# Altium schematic sheet Schematic.SchDoc(Schematic)
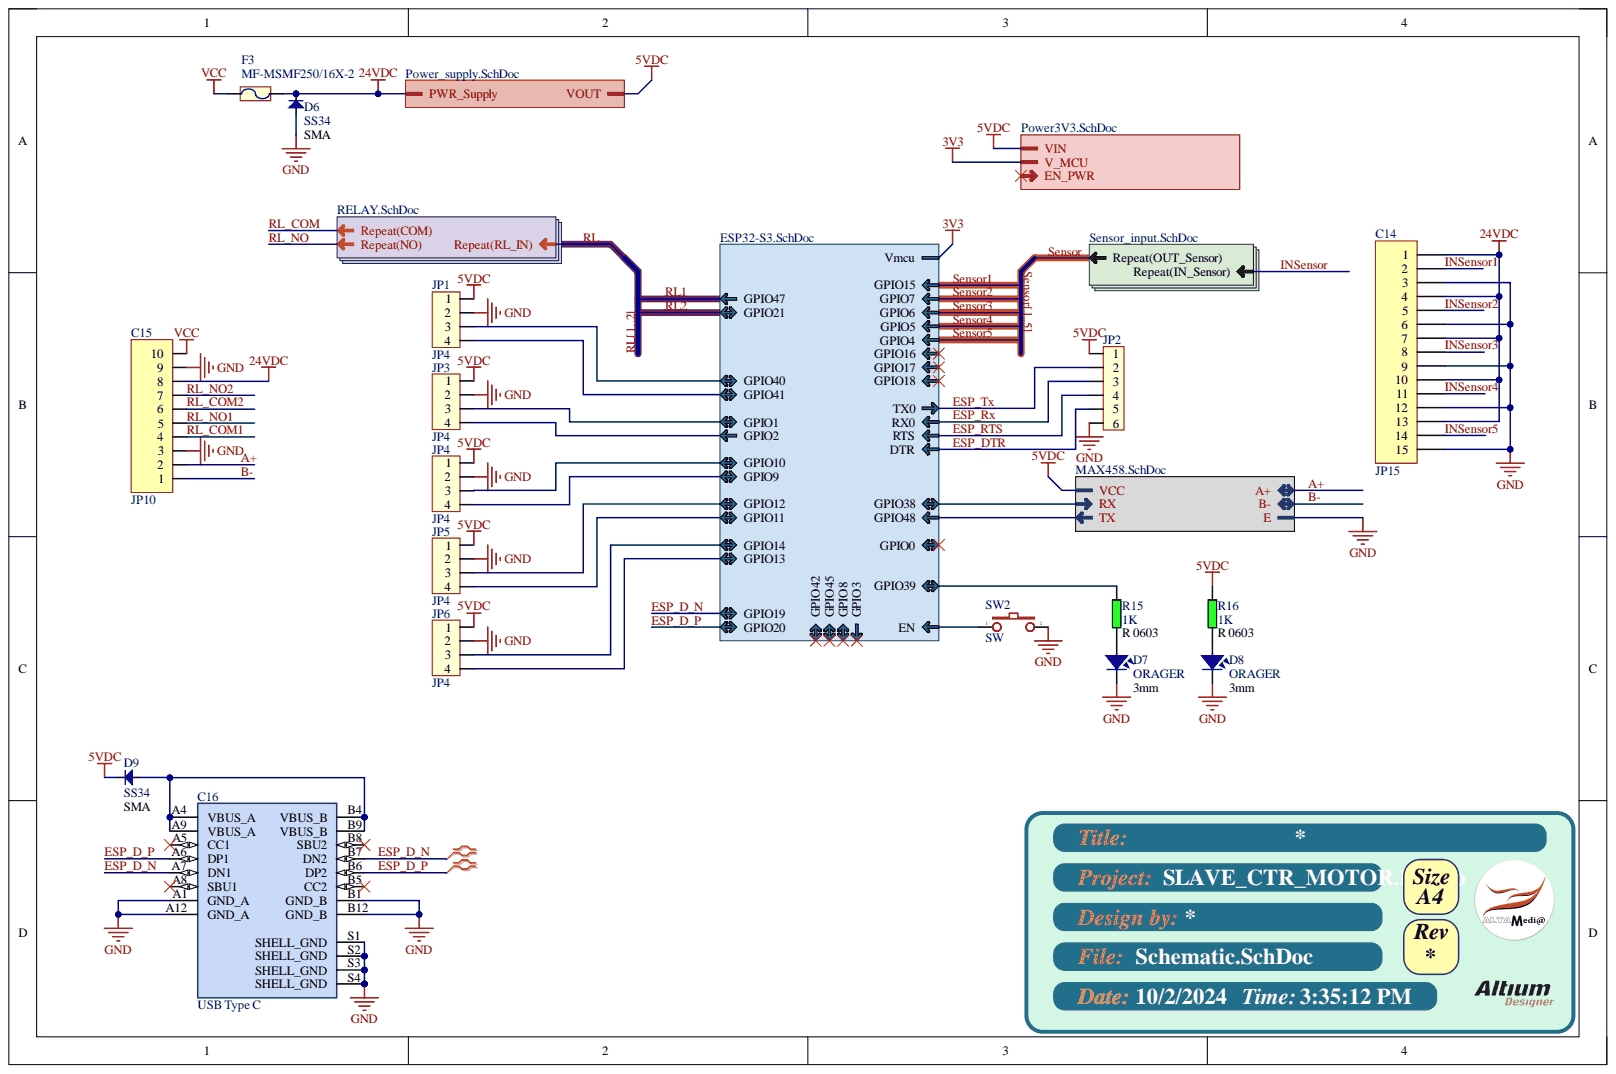
[diagram p0: Schematic.SchDoc]

## Parts
C14  JP15  [KF141-2.54-15]
C15  JP10  [KF141-2.54-10-R]
C16  USB Type C  [USB Type C 16P]
D6  SS34  Size: SMA  [DIO-SMD-106]
D7  ORAGER  Size: 3mm  [LED_RED_3MM]
D8  ORAGER  Size: 3mm  [LED_RED_3MM]
D9  SS34  Size: SMA  [DIO-SMD-106]
F3  MF-MSMF250/16X-2  [FUSER_MF-MSMF250/16X]
JP1  JP4  [CON4]
JP2  (no value)  [JP6]
JP3  JP4  [CON4]
JP4  JP4  [CON4_H]
JP5  JP4  [CON4_H]
JP6  JP4  [CON4_H]
R15  1K  [R-0603]
R16  1K  [R-0603]
SW2  SW  [TS36CA-0.6]

## Nets
5VDC: D9.2 JP1.1 JP2.1 JP3.1 JP4.1 JP5.1 JP6.1 R16.1
24VDC: C14.1 C14.4 C14.7 C14.10 C14.13 C15.8 D6.2 F3.2
GND: C14.3 C14.6 C14.9 C14.12 C14.15 C15.3 C15.9 C16.A1(GND_A) C16.A12(GND_A) C16.B1(GND_B) C16.B12(GND_B) C16.S1(SHELL_GND) C16.S2(SHELL_GND) C16.S3(SHELL_GND) C16.S4(SHELL_GND) D6.1 D7.2 D8.2 JP1.2 JP2.6 JP3.2 JP4.2 JP5.2 JP6.2 SW2.2
VCC: C15.10 F3.1
A+: C15.2
B-: C15.1
ESP_D_N: C16.A7(DN1) C16.B7(DN2)
ESP_D_P: C16.A6(DP1) C16.B6(DP2)
ESP_DTR: JP2.5
ESP_RTS: JP2.4
ESP_Rx: JP2.3
ESP_Tx: JP2.2
INSensor1: C14.2
INSensor2: C14.5
INSensor3: C14.8
INSensor4: C14.11
INSensor5: C14.14
RL_COM1: C15.4
RL_COM2: C15.6
RL_NO1: C15.5
RL_NO2: C15.7
NetC16_A4: C16.A4(VBUS_A) C16.A9(VBUS_A) C16.B4(VBUS_B) C16.B9(VBUS_B) D9.1
NetC16_A5: C16.A5(CC1)
NetC16_A8: C16.A8(SBU1)
NetC16_B5: C16.B5(CC2)
NetC16_B8: C16.B8(SBU2)
NetD7_1: D7.1 R15.2
NetD8_1: D8.1 R16.2
NetJP1_3: JP1.3
NetJP1_4: JP1.4
NetJP3_3: JP3.3
NetJP3_4: JP3.4
NetJP4_3: JP4.3
NetJP4_4: JP4.4
NetJP5_3: JP5.3
NetJP5_4: JP5.4
NetJP6_3: JP6.3
NetJP6_4: JP6.4
NetR15_1: R15.1
NetSW2_1: SW2.1
3V3:
EN_PWR:
GPIO0:
GPIO3:
GPIO8:
GPIO16:
GPIO17:
GPIO18:
GPIO38:
GPIO42:
GPIO45:
GPIO48:
RL1:  [also labelled RL]
RL2:  [also labelled RL]
Sensor1:  [also labelled Sensor]
Sensor2:  [also labelled Sensor]
Sensor3:  [also labelled Sensor]
Sensor4:  [also labelled Sensor]
Sensor5:  [also labelled Sensor]

## Library data of the parts
C14 = JP15: WR-PHD 2.54 mm Angled Socket Header; Manufacturer: W¨¹rth Elektronik eiSos GmbH; partNo: 613015143121; ComponentLink1 Description: Manufacturer; ComponentLink2 Description: Datasheet; NoPins: 15; Component Kind: Standard; ComponentLink1URL: http://www.we-online.com; ComponentLink2URL: http://katalog.we-online.de/em/datasheet/6130xx143121.pdf; Created Date: 29 March 2013; Library Name: My Library.SchLib; Created by: Karrer Zheng
C15 = JP10: WR-PHD 2.54 mm Angled Socket Header; Manufacturer: W¨¹rth Elektronik eiSos GmbH; partNo: 613010143121; ComponentLink1 Description: Manufacturer; ComponentLink2 Description: Datasheet; NoPins: 10; Component Kind: Standard; ComponentLink1URL: http://www.we-online.com; ComponentLink2URL: http://katalog.we-online.de/em/datasheet/6130xx143121.pdf; Created Date: 29 March 2013; Library Name: My Library.SchLib; Created by: Karrer Zheng
C16 = USB TypeC 16P: CSP-USC16-TR Valcon USB Type C Surface Mount PCB Socket; Availability: No availability data; Check_prices: https://www.snapeda.com/parts/CSP-USC16-TR/Valcon/view-part/4783629/?ref=eda; Component Kind: Standard; Library Name: My Library.SchLib; MF: Valcon; MP: CSP-USC16-TR; Package: None; Price: None; Purchase-URL: https://pricing.snapeda.com/search/part/CSP-USC16-TR/?ref=eda; SnapEDA_Link: https://www.snapeda.com/parts/CSP-USC16-TR/Valcon/view-part/4783629/?ref=snap; Value: USB Type C
D6 D9 = Diode SMD: Default Diode; Component Kind: Standard; Library Name: My Library.SchLib; Published: 8-Jun-2000; Revision: July-2002: Re-released for DXP Platform.; Value: SS34; Publisher: Altium Limited
D7 D8 = LED: LED Single colour Green and Red; FNC: Waring; Component Kind: Standard; Library Name: My Library.SchLib; Value: ORAGER
F3 = Fuse: Designed by: VanDungEvn; Component Kind: Standard; Description: Fuse; Library Name: My Library.SchLib; Value: MF-MSMF250/16X-2
JP1 JP3-JP6 = Con4: Connector 4; Component Kind: Standard; Library Name: My Library.SchLib; Value: Connector 4
JP2 = JP6: JP6 PIN; Value: JP7; Component Kind: Standard; Library Name: My Library.SchLib
R15 R16 = RES: SAISO: 5%; Size2: R 0805; Component Kind: Standard; Library Name: Schlib.SchLib; Size: R 0603; Value: 1K
SW2 = SW2: Switch button 2 pin; Value: SW chip 2 pin; Component Kind: Standard; Library Name: My Library.SchLib
